# Altium schematic sheet CURRENT_SOURCE.SchDoc
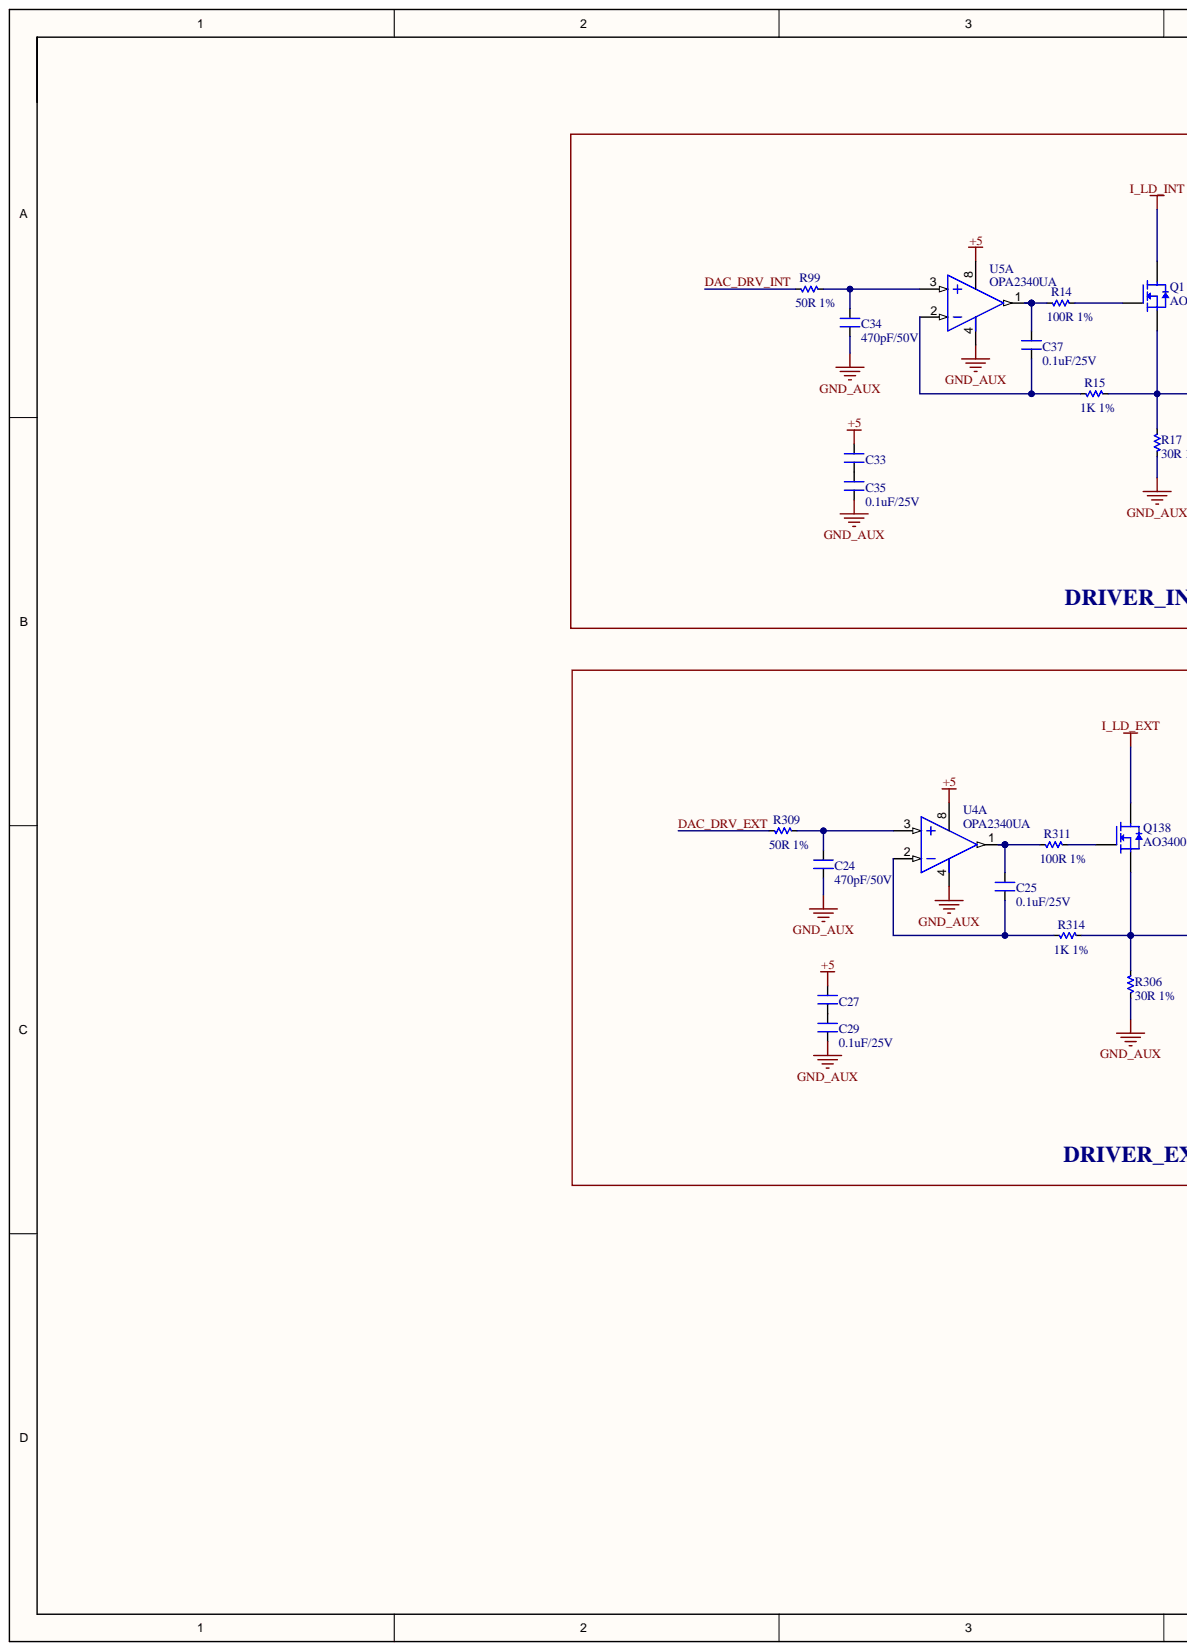
[diagram p0: CURRENT_SOURCE.SchDoc, left]
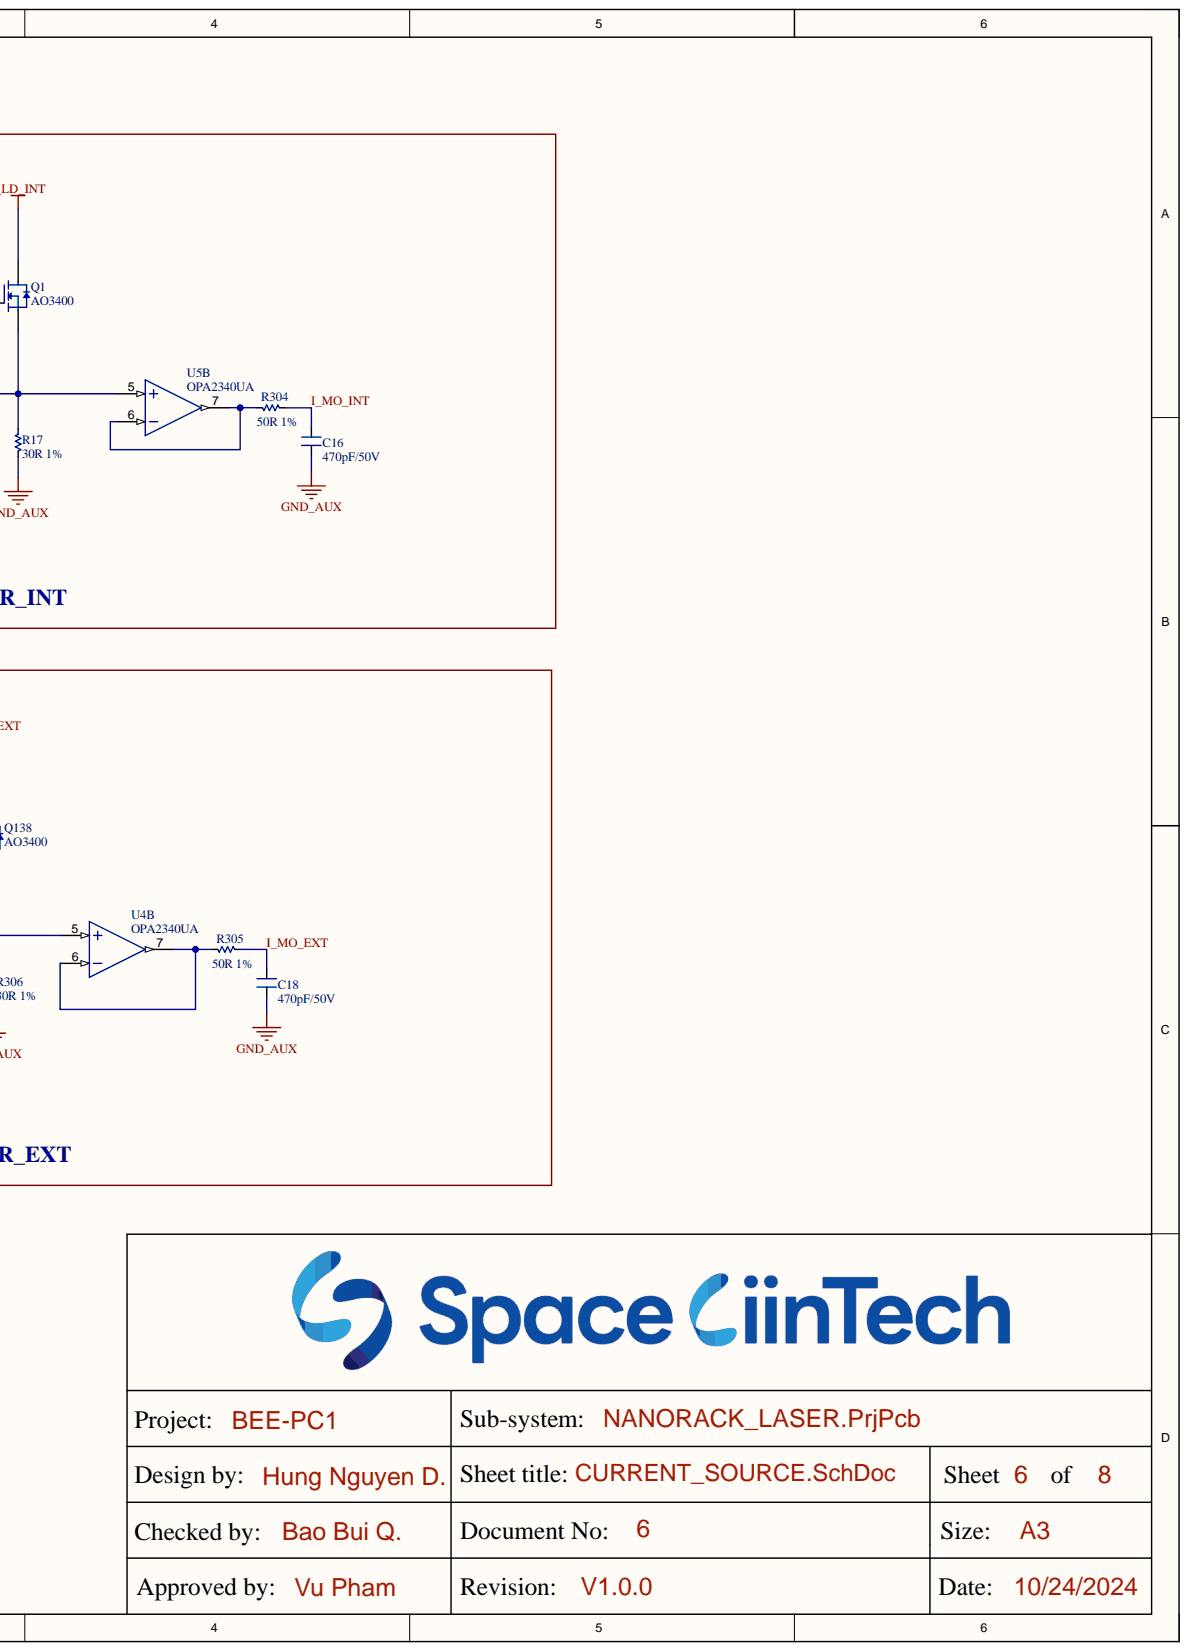
[diagram p1: CURRENT_SOURCE.SchDoc, right]

## Parts
C16  470pF/50V  [SM-0603]
C18  470pF/50V  [SM-0603]
C24  470pF/50V  [SM-0603]
C25  0.1uF/25V  [SM-0603]
C27  0.1uF/25V  [SM-0603]
C29  0.1uF/25V  [SM-0603]
C33  0.1uF/25V  [SM-0603]
C34  470pF/50V  [SM-0603]
C35  0.1uF/25V  [SM-0603]
C37  0.1uF/25V  [SM-0603]
Q1  AO3400  [SOT23-132]
Q138  AO3400  [SOT23-132]
R14  100R 1%  [RES-SMD-0603]
R15  1K 1%  [RES-SMD-0603]
R17  30R 1%  [RES-SMD-1206]
R99  50R 1%  [RES-SMD-0603]
R304  50R 1%  [RES-SMD-0603]
R305  50R 1%  [RES-SMD-0603]
R306  30R 1%  [RES-SMD-1206]
R309  50R 1%  [RES-SMD-0603]
R311  100R 1%  [RES-SMD-0603]
R314  1K 1%  [RES-SMD-0603]
U4  OPA2340UA  [SO8]  (parts A,B)
U5  OPA2340UA  [SO8]  (parts A,B)

## Nets
+5: C27.2 C33.2 U4.8 U5.8
GND_AUX: C16.1 C18.1 C24.1 C29.1 C34.1 C35.1 R17.1 R306.1 U4.4 U5.4
I_LD_EXT: Q138.3
I_LD_INT: Q1.3
DAC_DRV_EXT: R309.1
DAC_DRV_INT: R99.1
I_MO_EXT: C18.2 R305.2
I_MO_INT: C16.2 R304.2
NetC24_2: C24.2 R309.2 U4.3
NetC25_1: C25.1 R314.1 U4.2
NetC25_2: C25.2 R311.1 U4.1
NetC27_1: C27.1 C29.2
NetC33_1: C33.1 C35.2
NetC34_2: C34.2 R99.2 U5.3
NetC37_1: C37.1 R15.1 U5.2
NetC37_2: C37.2 R14.1 U5.1
NetQ1_1: Q1.1 R14.2
NetQ1_2: Q1.2 R15.2 R17.2 U5.5
NetQ138_1: Q138.1 R311.2
NetQ138_2: Q138.2 R306.2 R314.2 U4.5
NetR304_1: R304.1 U5.6 U5.7
NetR305_1: R305.1 U4.6 U4.7

## Library data of the parts
C16 C18 C24 C25 C27 C29 C33-C35 C37 = CM: 0603; Value: 103; Component Kind: Standard; Library Name: Capacitors.SchLib
Q1 Q138 = FET-N: Component Kind: Standard; Library Name: Library.SchLib
R14 R15 R17 R99 R304-R306 R309 R311 R314 = Res-SMD: 0603; Manufacturer: Generic Components; Class: Passive; Component Kind: Standard; Library Name: Library.SchLib; Published: 08/06/2000; Publisher: Altium Hobart Technology Centre
U4 U5 = LM358: 8SOIC; Value: LM358; Component Kind: Standard; Library Name: Library.SchLib
